# Altium schematic sheet Connectors.SchDoc(Connectors)
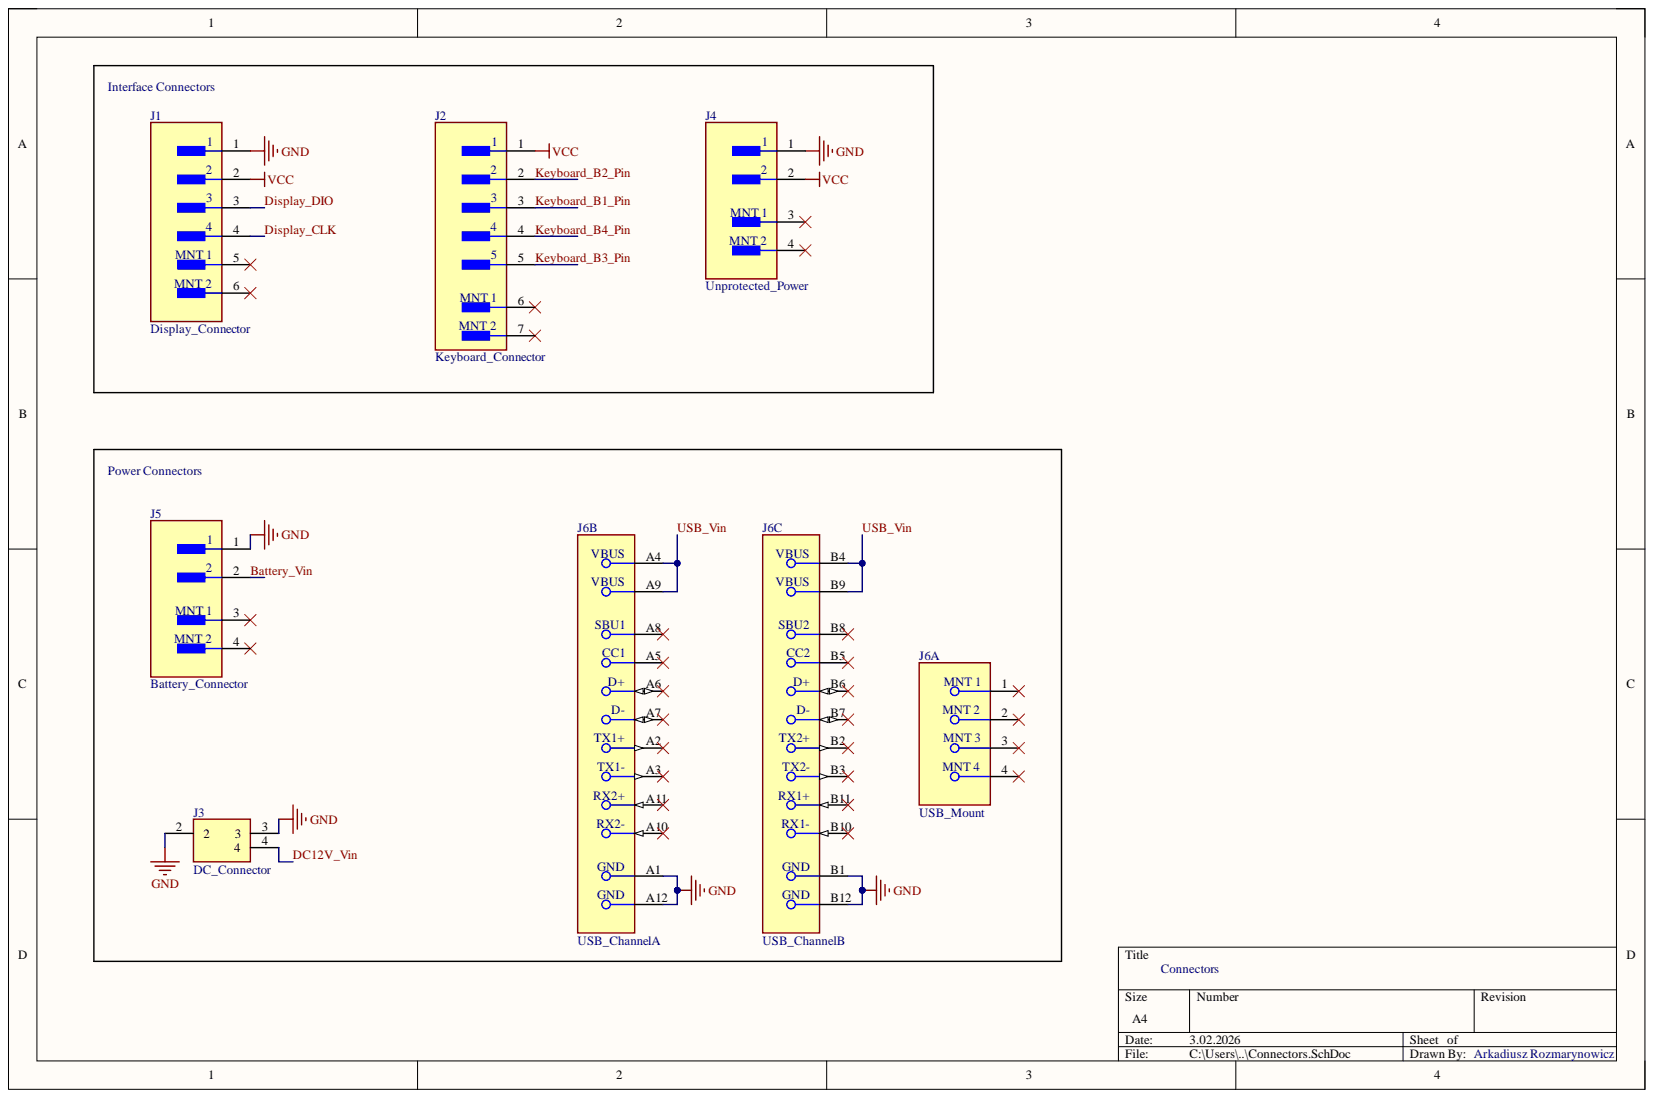
[diagram p0: Connectors.SchDoc]

## Parts
J1  Display_Connector  [FP-BM04B-GHS-TBT_LF_SN-MFG]
J2  Keyboard_Connector  [FP-BM05B-GHS-TBT_LF_SN-MFG]
J3  DC_Connector  [PCGK21]
J4  Unprotected_Power  [FP-SM02B-GHS-TB_LF_SN-MFG]
J5  Battery_Connector  [FP-SM02B-GHS-TB_LF_SN-MFG]
J6  USB_Mount  [FP-105455-0101-MFG]  (parts A,B,C)

## Nets
GND: J1.1 J3.2 J3.3 J4.1 J5.1 J6.A1 J6.A12 J6.B1 J6.B12
VCC: J1.2 J2.1 J4.2
Battery_Vin: J5.2
DC12V_Vin: J3.4
Display_CLK: J1.4
Display_DIO: J1.3
Keyboard_B1_Pin: J2.3
Keyboard_B2_Pin: J2.2
Keyboard_B3_Pin: J2.5
Keyboard_B4_Pin: J2.4
USB_Vin: J6.A4 J6.A9 J6.B4 J6.B9

Not connected: J1 5 6; J2 6 7; J4 3 4; J5 3 4; J6 1 2 3 4 A2 A3 A5 A6 A7 A8 A10 A11 B2 B3 B5 B6 B7 B8 B10 B11

## Library data of the parts
J1 = CMP-17439-000062-2: CONN HEADER SMD 4POS 1.25MM; Current Rating: 1A; Gender: Male; Number of Contacts: 4; Voltage Rating: 50V; Wire/Cable Gauge: 26AWG; Case/Package: SMD/SMT; Component Kind: Standard; Contact Material: Bronze; Contact Plating: Tin; Housing Color: Natural; Housing Material: Nylon; Lead Free: Lead Free; Library Name: Altium Content Vault; Max Operating Temperature: 85°C; Min Operating Temperature: -25°C; Number of Positions: 4; Number of Rows: 4; Orientation: Straight; Packaging: Cut Tape; Pitch: 1.25mm; REACH SVHC: No SVHC; Series: GH; Termination: Crimp; Voltage Rating (AC): 50V
J2 = CMP-17439-000467-1: CONN HEADER SMD 5POS 1.25MM; Mated Stacking Heights: 7.3mm; Number of Positions: 5; Pitch: 0.049inch; Voltage Rating: 50V; Color: Natural; Component Kind: Standard; Connector Type: Header; Contact Finish - Mating: TIN; Contact Material: Bronze; Contact Plating: Tin; Contact Shape: Rectangular; Contact Type: Outer Shroud Contact; Fastening Type: Ramp; Flammability Rating: UL94 V-0; Insulation Color: Natural; Insulation Material: Nylon; Lead Free: Lead Free; Library Name: Altium Content Vault; Max Operating Temperature: 85°C; Min Operating Temperature: -25°C; Mount: Surface Mount; Number of Pins: 5; Number of Rows: 1; Packaging: Cut Tape; Series: GH; Termination: Solder
J3 = PC-GK2.1: Socket; DC supply; male; 5.5/2.1mm; 5.5mm; 2.1mm; THT; angled 90; Manufacturer_Name: Ninigi; Geometry.Height: 11.2mm; Component Kind: Standard; Datasheet Link: https://www.tme.eu/Document/78afd4fb8a41e4211137cd818ea9e93d/PC-GK21.jpg; Library Name: PC-GK2_1.IntLib; Manufacturer_Part_Number: PC-GK2.1
J4 J5 = CMP-2000-07076-2: CONN HEADER SMD R/A 2POS 1.25MM; Connector Type: Outer Shroud Contact; Current Rating: 1A; Number of Contacts: 2; Pitch: 0.049inch; Voltage Rating: 50V; Color: Natural; Component Kind: Standard; Contact Material: Bronze; Contact Plating: Tin; Contact Type: Male Pin; Fastening Type: Latch; Housing Color: Natural; Housing Material: Nylon; Lead Free: Lead Free; Library Name: Altium Content Vault; Mount: Surface Mount; Number of Positions: 2; Number of Rows: 1; Orientation: Right Angle; Package Quantity: 2500; Packaging: Tape and Reel; Series: GH; Termination: Solder
J6 = CMP-04767-000019-1: CONN RCP USB3.1 TYPEC 24P SMD RA; Component Kind: Standard; Library Name: Altium Content Vault
